# Altium schematic sheet Sheet1.SchDoc(Sheet1)
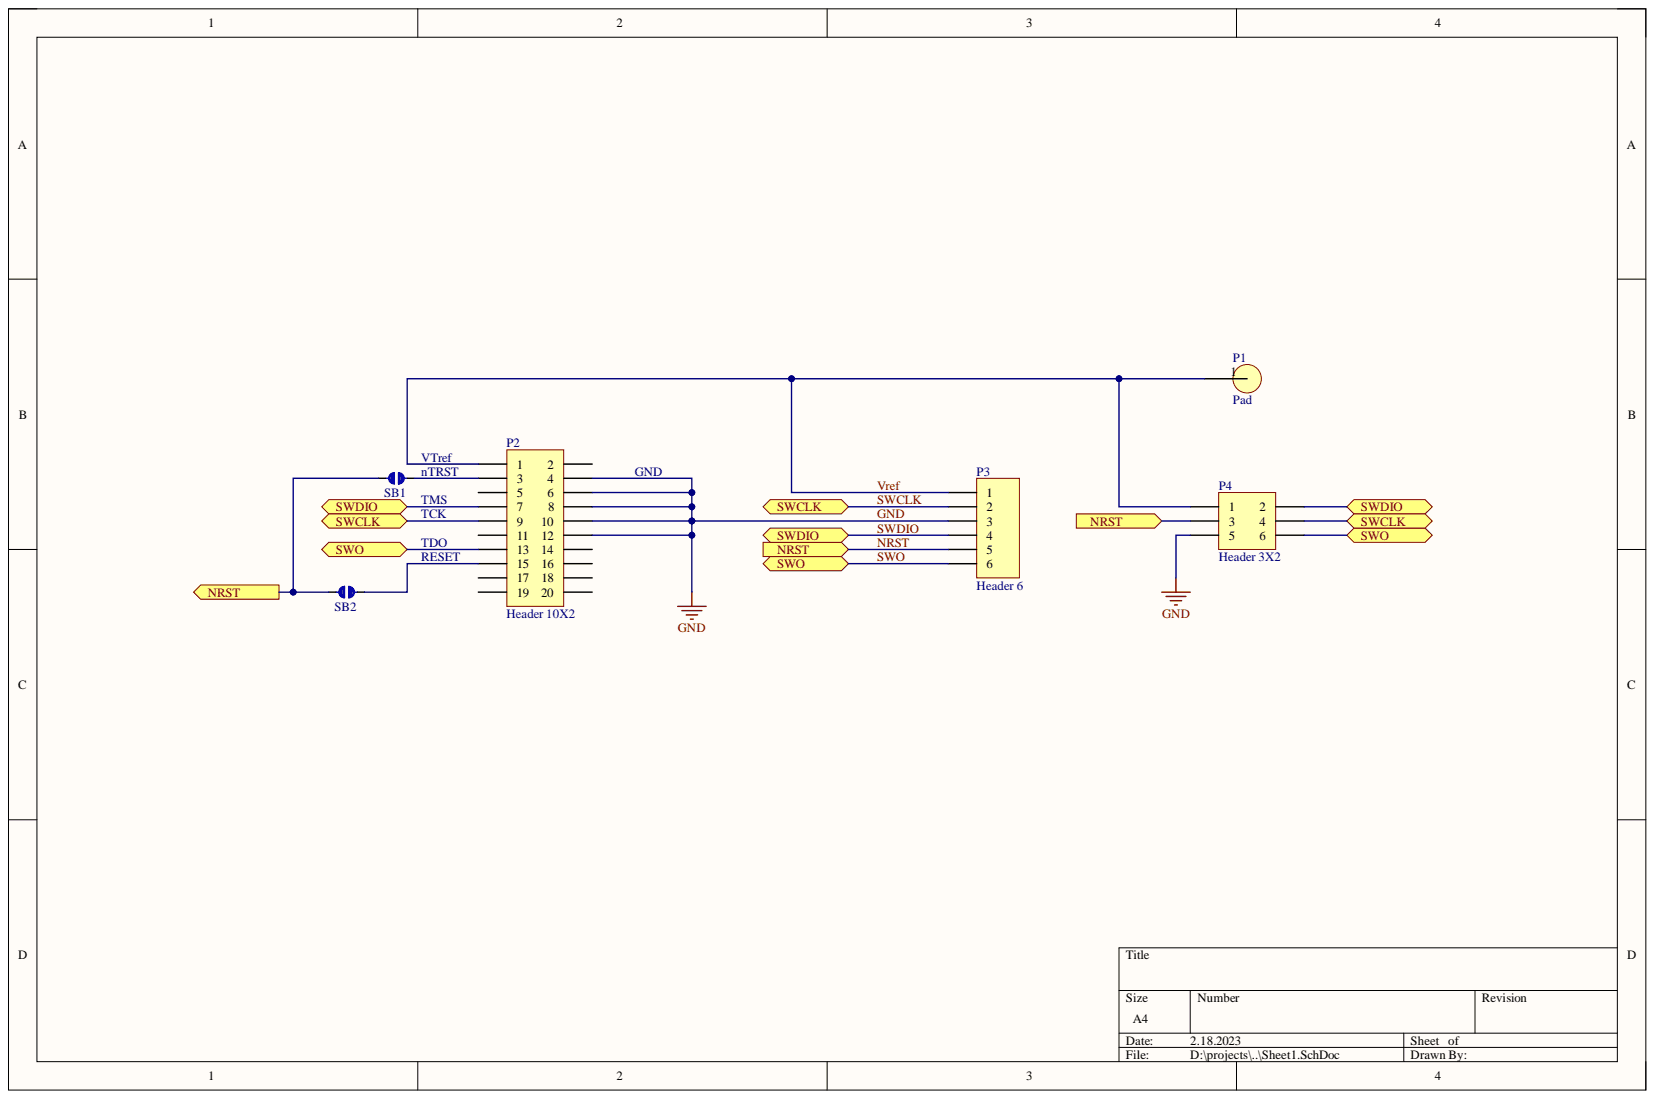
[diagram p0: Sheet1.SchDoc]

## Parts
P1  Pad  [pad 3x1.5]
P2  Header 10X2  [HDR2X10]
P3  Header 6  [HDR1X6]
P4  Header 3X2  [HDR2X3]
SB1  solder bridge  [solder_bridge]  (value not drawn)
SB2  solder bridge  [solder_bridge]  (value not drawn)

## Ports
NRST, SWCLK, SWDIO, SWO

## Nets
GND: P2.4 P2.6 P2.8 P2.10 P2.12 P3.3 P4.5
NRST: P3.5 P4.3 SB1.1 SB2.1 ; port NRST
SWCLK: P2.9 P3.2 P4.4 ; port SWCLK
SWDIO: P2.7 P3.4 P4.2 ; port SWDIO
SWO: P2.13 P3.6 P4.6 ; port SWO
Vref: P1.1 P2.1 P3.1 P4.1
NetP2_3: P2.3 SB1.2
NetP2_15: P2.15 SB2.2

Not connected: P2 2 5 11 14 16 17 18 19 20

## Library data of the parts
P1 = Pad: Simple solder pad; Component Kind: Standard; Library Name: Schlib1.SchLib
P2 = Header 10X2: Header, 10-Pin, Dual row; Component Kind: Standard; LatestRevisionDate: 17-Jul-2002; LatestRevisionNote: Re-released for DXP Platform.; Library Name: Miscellaneous Connectors.IntLib; Signal Integrity: Connector; Publisher: Altium Limited
P3 = Header 6: Header, 6-Pin; Component Kind: Standard; LatestRevisionDate: 17-Jul-2002; LatestRevisionNote: Re-released for DXP Platform.; Library Name: Miscellaneous Connectors.IntLib; Signal Integrity: Connector; Publisher: Altium Limited
P4 = Header 3X2: Header, 3-Pin, Dual row; Component Kind: Standard; LatestRevisionDate: 17-Jul-2002; LatestRevisionNote: Re-released for DXP Platform.; Library Name: Miscellaneous Connectors.IntLib; Signal Integrity: Connector; Publisher: Altium Limited
SB1 SB2 = solder bridge: Component Kind: Standard; Library Name: Schlib1.SchLib
